# Altium schematic sheet IMU & Connectors.SchDoc
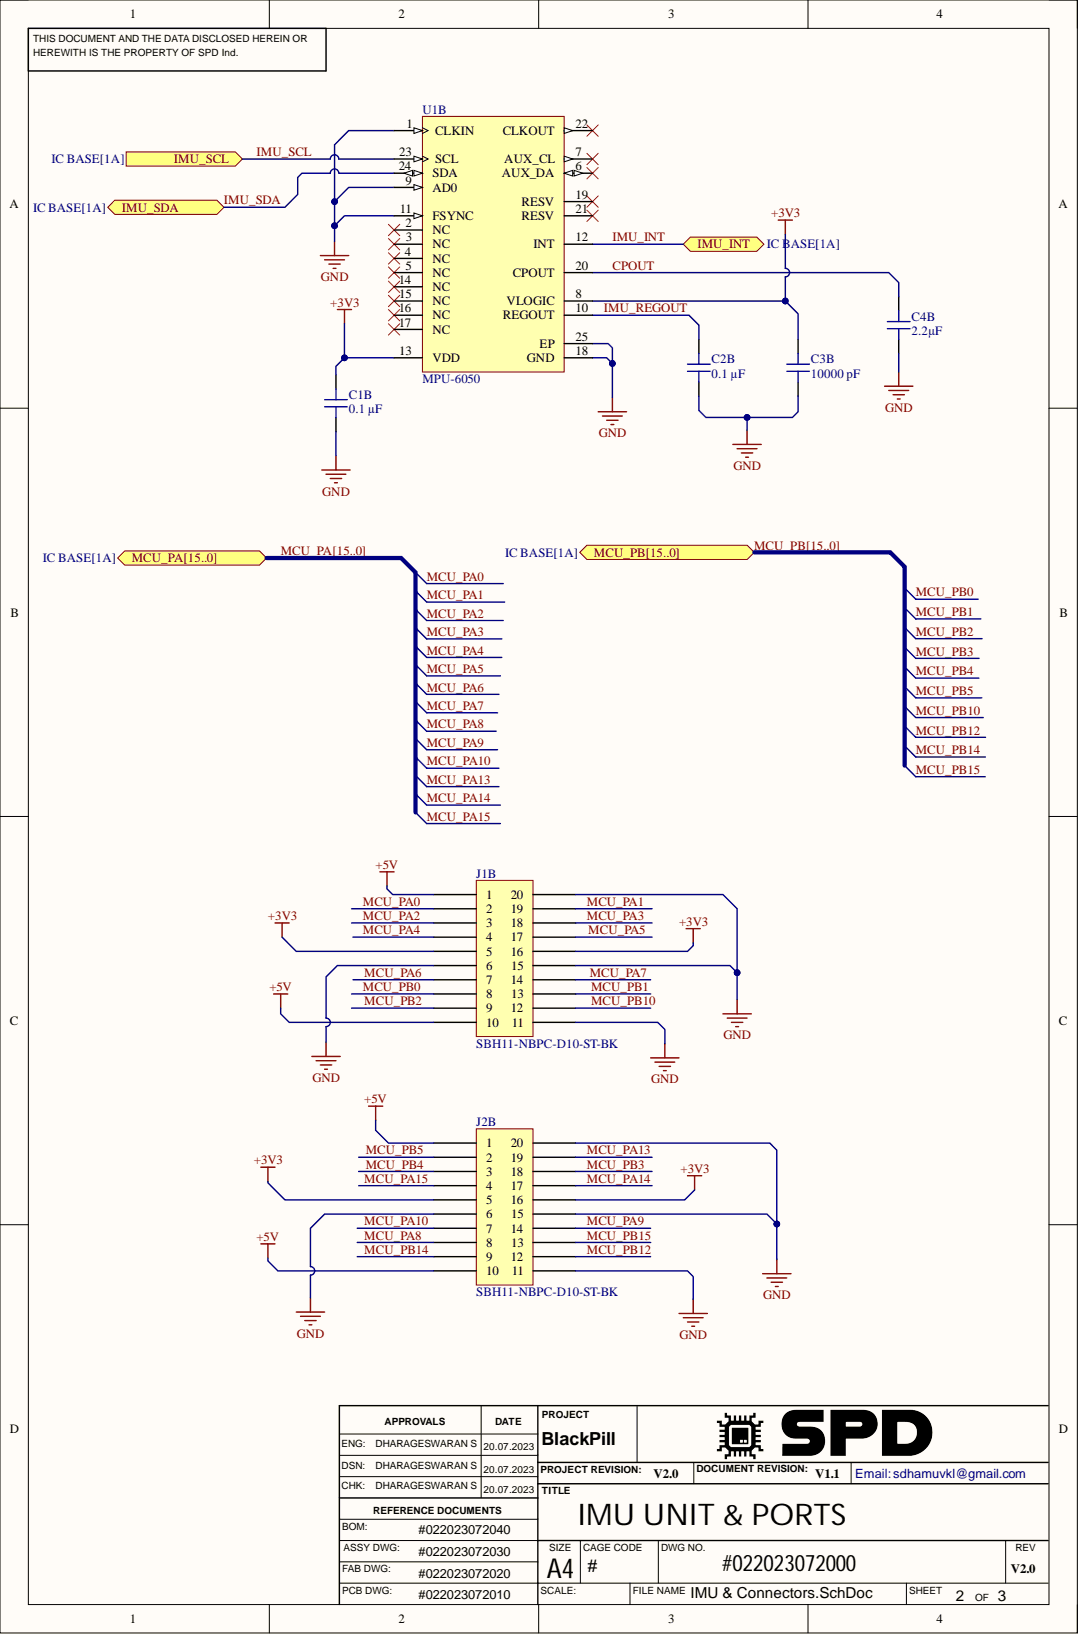
[diagram p0: IMU & Connectors.SchDoc]

## Parts
C1B  0.1 µF  [CAP 0402_1005]
C2B  0.1 µF  [CAP 0402_1005]
C3B  10000 pF  [CAP 0402_1005]
C4B  2.2µF  [CAP 0402_1005]
J1B  SBH11-NBPC-D10-ST-BK  [SULLINS SBH11-NBPC-D10-ST-BK]
J2B  SBH11-NBPC-D10-ST-BK  [SULLINS SBH11-NBPC-D10-ST-BK]
U1B  MPU-6050  [QFN50P400X400X95-24N]

## Ports
IMU_INT, IMU_SCL, IMU_SDA, MCU_PA0, MCU_PA1, MCU_PA2, MCU_PA3, MCU_PA4, MCU_PA5, MCU_PA6, MCU_PA7, MCU_PA8, MCU_PA9, MCU_PA10, MCU_PA11, MCU_PA12, MCU_PA13, MCU_PA14, MCU_PA15, MCU_PA[15..0], MCU_PB0, MCU_PB1, MCU_PB2, MCU_PB3, MCU_PB4, MCU_PB5, MCU_PB6, MCU_PB7, MCU_PB8, MCU_PB9, MCU_PB10, MCU_PB11, MCU_PB12, MCU_PB13, MCU_PB14, MCU_PB15, MCU_PB[15..0]

## Nets
+3V3: C1B.2 C3B.2 J1B.5 J1B.16 J2B.5 J2B.16 U1B.8(VLOGIC) U1B.13(VDD)
+5V: J1B.1 J1B.10 J2B.1 J2B.10
GND: C1B.1 C2B.1 C3B.1 C4B.1 J1B.6 J1B.11 J1B.15 J1B.20 J2B.6 J2B.11 J2B.15 J2B.20 U1B.1(CLKIN) U1B.9(AD0) U1B.11(FSYNC) U1B.18(GND) U1B.25(EP)
CPOUT: C4B.2 U1B.20(CPOUT)
IMU_INT: U1B.12(INT) ; port IMU_INT
IMU_REGOUT: C2B.2 U1B.10(REGOUT)
IMU_SCL: U1B.23(SCL) ; port IMU_SCL
IMU_SDA: U1B.24(SDA) ; port IMU_SDA
MCU_PA0: J1B.2 ; port MCU_PA[15..0]  [also labelled MCU_PA]
MCU_PA1: J1B.19 ; port MCU_PA[15..0]  [also labelled MCU_PA]
MCU_PA2: J1B.3 ; port MCU_PA[15..0]  [also labelled MCU_PA]
MCU_PA3: J1B.18 ; port MCU_PA[15..0]  [also labelled MCU_PA]
MCU_PA4: J1B.4 ; port MCU_PA[15..0]  [also labelled MCU_PA]
MCU_PA5: J1B.17 ; port MCU_PA[15..0]  [also labelled MCU_PA]
MCU_PA6: J1B.7 ; port MCU_PA[15..0]  [also labelled MCU_PA]
MCU_PA7: J1B.14 ; port MCU_PA[15..0]  [also labelled MCU_PA]
MCU_PA8: J2B.8 ; port MCU_PA[15..0]  [also labelled MCU_PA]
MCU_PA9: J2B.14 ; port MCU_PA[15..0]  [also labelled MCU_PA]
MCU_PA10: J2B.7 ; port MCU_PA[15..0]  [also labelled MCU_PA]
MCU_PA13: J2B.19 ; port MCU_PA[15..0]  [also labelled MCU_PA]
MCU_PA14: J2B.17 ; port MCU_PA[15..0]  [also labelled MCU_PA]
MCU_PA15: J2B.4 ; port MCU_PA[15..0]  [also labelled MCU_PA]
MCU_PB0: J1B.8 ; port MCU_PB[15..0]  [also labelled MCU_PB]
MCU_PB1: J1B.13 ; port MCU_PB[15..0]  [also labelled MCU_PB]
MCU_PB2: J1B.9 ; port MCU_PB[15..0]  [also labelled MCU_PB]
MCU_PB3: J2B.18 ; port MCU_PB[15..0]  [also labelled MCU_PB]
MCU_PB4: J2B.3 ; port MCU_PB[15..0]  [also labelled MCU_PB]
MCU_PB5: J2B.2 ; port MCU_PB[15..0]  [also labelled MCU_PB]
MCU_PB10: J1B.12 ; port MCU_PB[15..0]  [also labelled MCU_PB]
MCU_PB12: J2B.12 ; port MCU_PB[15..0]  [also labelled MCU_PB]
MCU_PB14: J2B.9 ; port MCU_PB[15..0]  [also labelled MCU_PB]
MCU_PB15: J2B.13 ; port MCU_PB[15..0]  [also labelled MCU_PB]
MCU_PA11: ; port MCU_PA[15..0]  [also labelled MCU_PA]
MCU_PA12: ; port MCU_PA[15..0]  [also labelled MCU_PA]
MCU_PB6: ; port MCU_PB[15..0]  [also labelled MCU_PB]
MCU_PB7: ; port MCU_PB[15..0]  [also labelled MCU_PB]
MCU_PB8: ; port MCU_PB[15..0]  [also labelled MCU_PB]
MCU_PB9: ; port MCU_PB[15..0]  [also labelled MCU_PB]
MCU_PB11: ; port MCU_PB[15..0]  [also labelled MCU_PB]
MCU_PB13: ; port MCU_PB[15..0]  [also labelled MCU_PB]

Not connected: U1B 2(NC) 3(NC) 4(NC) 5(NC) 6(AUX_DA) 7(AUX_CL) 14(NC) 15(NC) 16(NC) 17(NC) 19(RESV) 21(RESV) 22(CLKOUT)

## Library data of the parts
C1B C2B = CL05B104KP5VPNC: CAP CER 100NF 10V X7R 0402; Manufacturer: Samsung Electro-Mechanics; Database Table Name: altium.Capacitors-Ceramic-0402; id: 7736; Tolerance: ±10%; Applications: Automotive; Capacitance: 0.1 µF; Component Kind: Standard; ComponentLink1Description: Datasheet; ComponentLink1URL: https://mm.digikey.com/Volume0/opasdata/d220001/medias/docus/5458/CL05B104KP5VPNC_Spec.pdf; ComponentLink2Description: DigiKey Link; ComponentLink2URL: https://www.digikey.com/en/products/detail/samsung-electro-mechanics/CL05B104KP5VPNC/20498482; Device Package: 0402 (1005 Metric); LastUpdated: 2023-11-05T20:02:55.743; Lifecycle Status: Active; Minimum Order: 1; Mounting Type: Surface Mount, MLCC; Operating Temperature: -55°C ~ 125°C; Package / Case: 0402 (1005 Metric); Packaging: TapeAndReel; Part Number: CL05B104KP5VPNC; PartId: 56690; Price: 0.01; Ratings: AEC-Q200; Series: CL; Size / Dimension: 0.039" L x 0.020" W (1.00mm x 0.50mm); Supplier 1: DigiKey; Supplier Part Number 1: 1276-CL05B104KP5VPNCCT-ND; Temperature Coefficient: X7R; Thickness (Max): 0.022" (0.55mm); Value: 0.1 µF; Voltage - Rated: 10V; Library Name: Postgres Altium Library - altium_library.DbLib
C3B = C0402C103K4REC7411: CAP CER 0402 10NF 16V X7R 10%; Manufacturer: KEMET; Database Table Name: altium.Capacitors-Ceramic-0402; id: 7587; Applications: ESD Protection; Capacitance: 10000 pF; Component Kind: Standard; ComponentLink1Description: Datasheet; ComponentLink1URL: https://connect.kemet.com:7667/gateway/IntelliData-ComponentDocumentation/1.0/download/datasheet/C0402C103K4REC7411; ComponentLink2Description: DigiKey Link; ComponentLink2URL: https://www.digikey.com/en/products/detail/kemet/C0402C103K4REC7411/8645017; Device Package: 0402 (1005 Metric); LastUpdated: 2023-08-20T19:02:59.890; Lifecycle Status: Active; Minimum Order: 1; Mounting Type: Surface Mount, MLCC; Operating Temperature: -55°C ~ 125°C; Package / Case: 0402 (1005 Metric); Packaging: TapeAndReel; Part Number: C0402C103K4REC7411; PartId: 56100; Price: 0.01; Series: ESD SMD Comm X7R; Size / Dimension: 0.039" L x 0.020" W (1.00mm x 0.50mm); Supplier 1: DigiKey; Supplier Part Number 1: 399-C0402C103K4REC7411CT-ND; Temperature Coefficient: X7R; Thickness (Max): 0.022" (0.55mm); Tolerance: ±10%; Value: 10000 pF; Voltage - Rated: 16V; Library Name: Postgres Altium Library - altium_library.DbLib
C4B = 0402ZD225KAT2A: CAP CER 2.2UF 10V X5R 0402; Manufacturer: AVX Corporation; Database Table Name: altium.Capacitors-Ceramic-0402; id: 2335; Tolerance: ±10%; Applications: General Purpose; Capacitance: 2.2µF; Component Kind: Standard; ComponentLink1Description: Datasheet; ComponentLink1URL: http://datasheets.avx.com/cx5r.pdf; ComponentLink2Description: DigiKey Link; ComponentLink2URL: http://digikey.com/product-detail/en/avx-corporation/0402ZD225KAT2A/478-10072-1-ND/6564294; Device Package: 0402 (1005 Metric); LastUpdated: 2022-02-08T12:26:45.707; Lifecycle Status: Active; Minimum Order: 1; Mounting Type: Surface Mount, MLCC; Operating Temperature: -55°C ~ 85°C; Package / Case: 0402 (1005 Metric); Packaging: TapeAndReel; Part Number: 0402ZD225KAT2A; Part Status: Active; PartId: 9346; Price: 0.09; Series: -; Size / Dimension: 0.039" L x 0.020" W (1.00mm x 0.50mm); Supplier 1: DigiKey; Supplier Part Number 1: 478-10072-1-ND; Temperature Coefficient: X5R; Thickness (Max): 0.022" (0.56mm); Value: 2.2µF; Voltage - Rated: 10V; Library Name: Postgres Altium Library - altium_library.DbLib
J1B J2B = SBH11-NBPC-D10-ST-BK: CONN HEADER VERT 20POS 2.54MM; Manufacturer: Sullins Connector Solutions; Current Rating (Amps): 3A; Database Table Name: altium.Connectors-RectangularHeadersMalePins; id: 135; Material Flammability Rating: UL94 V-0; Number Of Positions: 20; Number Of Positions Loaded: All; Shrouding: Shrouded - 4 Wall; Style: Board to Board or Cable; Component Kind: Standard; ComponentLink1Description: Datasheet; ComponentLink1URL: https://s3.amazonaws.com/catalogspreads-pdf/PAGE122%20.100%20SBH11%20SERIES%20MALE%20BOX%20HDR%20ST%20RA%20SMT.pdf; ComponentLink2Description: DigiKey Link; ComponentLink2URL: http://digikey.com/product-detail/en/sullins-connector-solutions/SBH11-NBPC-D10-ST-BK/SBH11-NBPC-D10-ST-BK-ND/4558585; Connector Type: Header; Contact Finish - Mating: Gold; Contact Finish - Post: Gold; Contact Finish Thickness - Mating: Flash; Contact Material: Brass; Contact Shape: Square; Contact Type: Male Pin; Fastening Type: Push-Pull; Features: Keying Slot; Insulation Color: Black; Insulation Material: Polyamide (PA), Nylon; LastUpdated: 2022-06-21T14:45:11.303; Lifecycle Status: Active; Minimum Order: 1000; Mounting Type: Through Hole; Number Of Rows: 2; Operating Temperature: -40°C ~ 105°C; Packaging: Tray; Part Number: SBH11-NBPC-D10-ST-BK; Part Status: Active; PartId: 135; Price: 0.40; Series: SBH11; Supplier 1: DigiKey; Supplier Part Number 1: SBH11-NBPC-D10-ST-BK-ND; Termination: Solder; Library Name: Postgres Altium Library - altium_library.DbLib
U1B = MPU-6050: MPU-6050 series Accelerometer, Gyroscope, 3 Axis Sensor Evaluation Board; MF: TDK InvenSense; Check_prices: https://www.snapeda.com/parts/MPU-6050/TDK+InvenSense/view-part/?ref=eda; Component Kind: Standard; Library Name: MPU-6050.IntLib; MP: MPU-6050; Package: QFN-24 InvenSense; SnapEDA_Link: https://www.snapeda.com/parts/MPU-6050/TDK+InvenSense/view-part/?ref=snap; Supplier 1: Digikey; Supplier Part Number 1: 1428-1007-1-ND
